# Altium schematic sheet HeartAware_Main.SchDoc
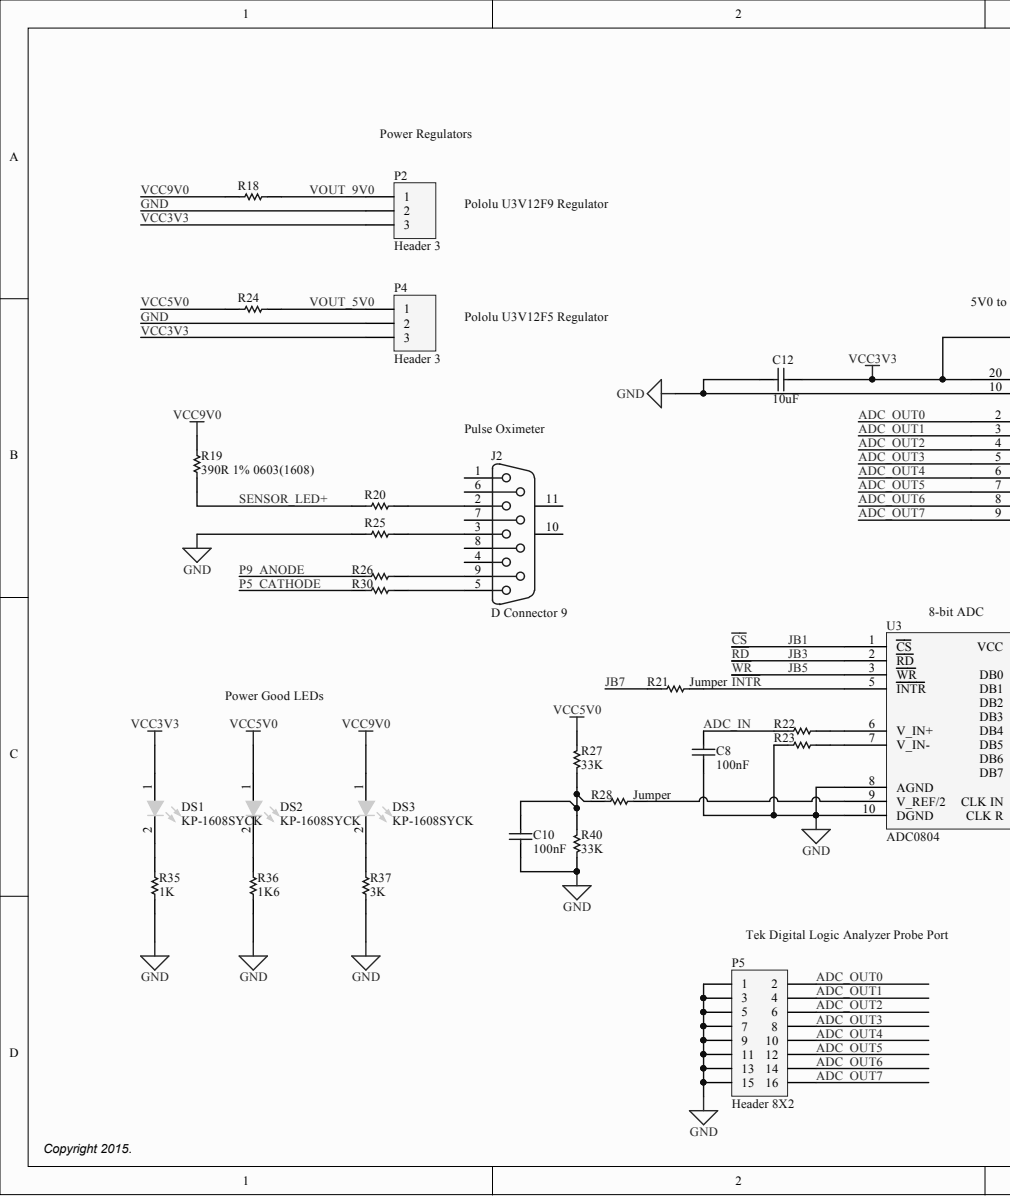
[diagram p0: HeartAware_Main.SchDoc, left]
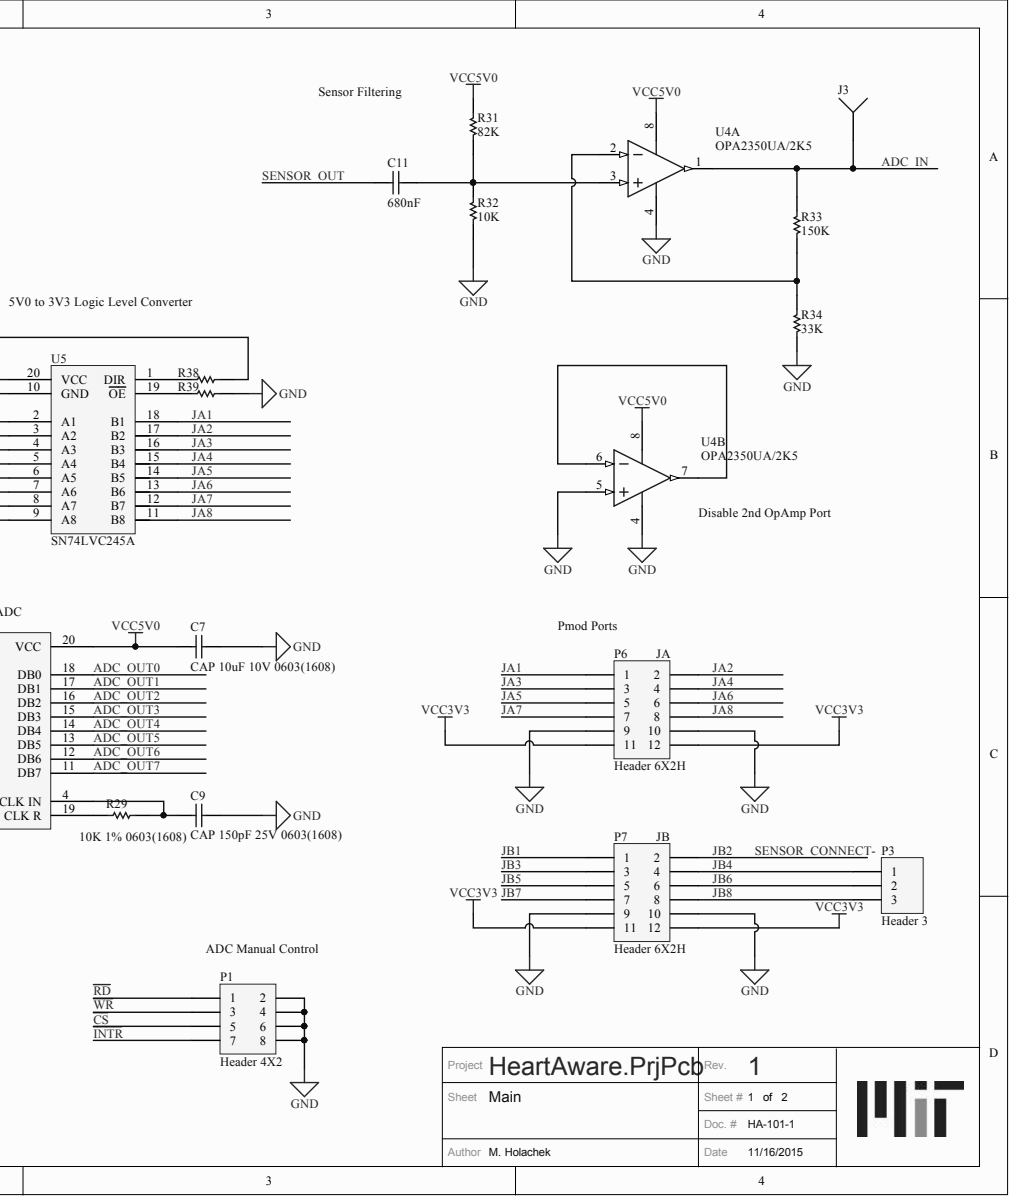
[diagram p1: HeartAware_Main.SchDoc, right]

## Parts
C7  CAP 10uF 10V 0603(1608)  value 10uF  [CAPC0603(1608)100_N]
C8  100nF  [CAPC0603(1608)100_M]
C9  CAP 150pF 25V 0603(1608)  value 150pF  [CAPC0603(1608)100_L]
C10  100nF  [CAPC0603(1608)100_M]
C11  680nF  [CAPC0603(1608)100_N]
C12  10uF  [CAPC0603(1608)100_N]
DS1  KP-1608SYCK  [KING-0603LED_YELLOW_V]
DS2  KP-1608SYCK  [KING-0603LED_YELLOW_V]
DS3  KP-1608SYCK  [KING-0603LED_YELLOW_V]
J2  D Connector 9  [DSUB1.385-2H9]
J3  Socket  [PIN1]  (value not drawn)
P1  Header 4X2  [HDR2X4]
P2  Header 3  [HDR1X3]
P3  Header 3  [HDR1X3]
P4  Header 3  [HDR1X3]
P5  Header 8X2  [HDR2X8]
P6  Header 6X2H  [HDR2X6H]
P7  Header 6X2H  [HDR2X6H]
R18  Jumper 0603(1608)  [RESC0603(1608)_N]  (value not drawn)
R19  390R 1% 0603(1608)  [RESC0603(1608)_L]
R20  Jumper 0603(1608)  [RESC0603(1608)_L]  (value not drawn)
R21  Jumper  [RESC0603(1608)_N]
R22  Jumper  [RESC0603(1608)_N]  (value not drawn)
R23  Jumper  [RESC0603(1608)_N]  (value not drawn)
R24  Jumper 0603(1608)  [RESC0603(1608)_N]  (value not drawn)
R25  Jumper 0603(1608)  [RESC0603(1608)_L]  (value not drawn)
R26  Jumper 0603(1608)  [RESC0603(1608)_L]  (value not drawn)
R27  33K  [RESC0603(1608)_N]
R28  Jumper  [RESC0603(1608)_N]
R29  10K 1% 0603(1608)  [RESC0603(1608)_N]
R30  Jumper 0603(1608)  [RESC0603(1608)_L]  (value not drawn)
R31  82K  [RESC0603(1608)_N]
R32  10K  [RESC0603(1608)_N]
R33  150K  [RESC0603(1608)_L]
R34  33K  [RESC0603(1608)_N]
R35  1K  [RESC0603(1608)_M]
R36  1K6  [RESC0603(1608)_L]
R37  3K  [RESC0603(1608)_N]
R38  Jumper 0603(1608)  [RESC0603(1608)_N]  (value not drawn)
R39  Jumper 0603(1608)  [RESC0603(1608)_N]  (value not drawn)
R40  33K  [RESC0603(1608)_N]
U3  ADC0804  [SOIC127P1030X265-20N]
U4  OPA2350UA/2K5  [TI-D8_M]  (parts A,B)
U5  SN74LVC245A  [SOIC127P780X200-20N]

## Nets
~{CS}: P1.5 P7.1 U3.1(CS)
~{INTR}: P1.7 R21.2 U3.5(INTR)
~{RD}: P1.1 P7.3 U3.2(RD)
~{WR}: P1.3 P7.5 U3.3(WR)
ADC_IN: C8.2 J3.1 R22.1 R33.1 U4.1
ADC_OUT0: P5.2 U3.18(DB0) U5.2(A1)
ADC_OUT1: P5.4 U3.17(DB1) U5.3(A2)
ADC_OUT2: P5.6 U3.16(DB2) U5.4(A3)
ADC_OUT3: P5.8 U3.15(DB3) U5.5(A4)
ADC_OUT4: P5.10 U3.14(DB4) U5.6(A5)
ADC_OUT5: P5.12 U3.13(DB5) U5.7(A6)
ADC_OUT6: P5.14 U3.12(DB6) U5.8(A7)
ADC_OUT7: P5.16 U3.11(DB7) U5.9(A8)
GND: C7.1 C8.1 C9.2 C10.1 C12.2 P1.2 P1.4 P1.6 P1.8 P2.2 P4.2 P5.1 P5.3 P5.5 P5.7 P5.9 P5.11 P5.13 P5.15 P6.9 P6.10 P7.9 P7.10 R23.1 R25.1 R32.2 R34.2 R35.1 R36.1 R37.1 R39.2 R40.2 U3.8(AGND) U3.10(DGND) U4.4 U4.5 U5.10(GND)
JA1: P6.1 U5.18(B1)
JA2: P6.2 U5.17
JA3: P6.3 U5.16
JA4: P6.4 U5.15
JA5: P6.5 U5.14
JA6: P6.6 U5.13
JA7: P6.7 U5.12
JA8: P6.8 U5.11
JB2: P7.2
JB4: P3.1 P7.4
JB6: P3.2 P7.6
JB7: P7.7 R21.1
JB8: P3.3 P7.8
P5_CATHODE: R30.1
P9_ANODE: R26.1
SENSOR_LED+: R19.1 R20.1
SENSOR_OUT: C11.1
VCC3V3: C12.1 DS1.1 P2.3 P4.3 P6.11 P6.12 P7.11 P7.12 R38.2 U5.20(VCC)
VCC5V0: C7.2 DS2.1 R24.1 R27.1 R31.1 U3.20(VCC) U4.8
VCC9V0: DS3.1 R18.1 R19.2
VOUT_5V0: P4.1 R24.2
VOUT_9V0: P2.1 R18.2
NetC9_1: C9.1 R29.2 U3.4(CLK_IN)
NetC10_2: C10.2 R27.2 R28.1 R40.1
NetC11_2: C11.2 R31.2 R32.1 U4.3
NetDS1_2: DS1.2 R35.2
NetDS2_2: DS2.2 R36.2
NetDS3_2: DS3.2 R37.2
NetJ2_2: J2.2 R20.2
NetJ2_3: J2.3 R25.2
NetJ2_5: J2.5 R30.2
NetJ2_9: J2.9 R26.2
NetR22_2: R22.2 U3.6(V_IN+)
NetR23_2: R23.2 U3.7(V_IN-)
NetR28_2: R28.2 U3.9(V_REF/2)
NetR29_1: R29.1 U3.19(CLK_R)
NetR33_2: R33.2 R34.1 U4.2
NetR38_1: R38.1 U5.1(DIR)
NetR39_1: R39.1 U5.19(OE)
NetU4_6: U4.6 U4.7

Not connected: J2 1 4 6 7 8 10 11

## Library data of the parts
C7 C12 = CMP-1035-02799-1: CAP 10uF 10V Â±10% 0603 (1608 Metric) Thickness 1mm SMD; Case-EIA: 0603; Case-Metric: 1608; Component Kind: Standard; Library Name: Altium Content Vault; Max Thickness: 1 mm; Rated Voltage: 10 V; Technology: SMT; Tolerance: Â±10%
  C12: Value: 10uF
C8 C10 = CMP-1035-02588-1: CAP 100nF 25V Â±10% 0603 (1608 Metric) Thickness 1mm SMD; Case-EIA: 0603; Case-Metric: 1608; Component Kind: Standard; Library Name: Altium Content Vault; Max Thickness: 1 mm; Rated Voltage: 25 V; Technology: SMT; Tolerance: Â±10%; Value: 100nF
C9 = CMP-1035-01361-1: CAP 150pF 25V Â±2% 0603 (1608 Metric) Thickness 1mm SMD; Case-EIA: 0603; Case-Metric: 1608; Component Kind: Standard; Library Name: Altium Content Vault; Max Thickness: 1 mm; Rated Voltage: 25 V; Technology: SMT; Tolerance: Â±2%
C11 = CMP-1035-02722-1: CAP 680nF 25V Â±10% 0603 (1608 Metric) Thickness 1mm SMD; Case-EIA: 0603; Case-Metric: 1608; Component Kind: Standard; Library Name: Altium Content Vault; Max Thickness: 1 mm; Rated Voltage: 25 V; Technology: SMT; Tolerance: Â±10%; Value: 680nF
DS1-DS3 = CMP-0404-00003-1: LED, SMT, 0603(1608), 1.1mm thickness, Yellow; Manufacturer: Kingbright; Forward Current: 30 mA; Packing Type: 2000 pcs / reel; Power Dissipation: 75 mW; Reverse Voltage: 5 V; Component Kind: Standard; ComponentLink1Description: Manufacturer URL; ComponentLink1URL: http://www.kingbright.com/; ComponentLink2Description: Datasheet; ComponentLink2URL: http://www.kingbright.com/manager/upload/pdf/(1366274739)KP-1608SYCK(Ver.18B).pdf; Library Name: Altium Content Vault; Mounting Technology: Surface Mount; PackageDescription: 2-Pin SMT LED, 1.6 x 0.8 mm Pitch; PackageReference: 0603LED_YELLOW; PartNumber: KP-1608SYCK; Storage Temperature: -40 to +85 degC
J2 = D Connector 9: Receptacle Assembly, 9 Position, Right Angle; PackageDocument: O; Positions: 9; Component Kind: Standard; DatasheetDocument: 1-Sep-1999; Gender: Receptacle; LatestRevisionDate: 17-Jul-2002; LatestRevisionNote: Re-released for DXP Platform.; Library Name: Miscellaneous Connectors.IntLib; Orientation: Right Angle; PackageReference: 788750; Published: 15-Jun-2001; Signal Integrity: Connector; Publisher: Altium Limited
P1 = Header 4X2: Header, 4-Pin, Dual row; Component Kind: Standard; LatestRevisionDate: 17-Jul-2002; LatestRevisionNote: Re-released for DXP Platform.; Library Name: Miscellaneous Connectors.IntLib; Signal Integrity: Connector; Publisher: Altium Limited
P2-P4 = Header 3: Header, 3-Pin; Component Kind: Standard; LatestRevisionDate: 17-Jul-2002; LatestRevisionNote: Re-released for DXP Platform.; Library Name: Miscellaneous Connectors.IntLib; Signal Integrity: Connector; Publisher: Altium Limited
P5 = Header 8X2: Header, 8-Pin, Dual row; Component Kind: Standard; LatestRevisionDate: 17-Jul-2002; LatestRevisionNote: Re-released for DXP Platform.; Library Name: Miscellaneous Connectors.IntLib; Signal Integrity: Connector; Publisher: Altium Limited
P6 P7 = Header 6X2H: Header, 6-Pin, Dual row, Right Angle; Component Kind: Standard; LatestRevisionDate: 17-Jul-2002; LatestRevisionNote: Re-released for DXP Platform.; Library Name: Miscellaneous Connectors.IntLib; Signal Integrity: Connector; Publisher: Altium Limited
R18 R20-R26 R28 R30 R38 R39 = CMP-1012-00001-1: Jumper 0603 (1608 Metric); Case-EIA: 0603; Case-Metric: 1608; Power: None; Value: 0.00 Ohm; Component Kind: Standard; Library Name: Altium Content Vault; Technology: SMT; Tolerance: None
R19 = CMP-1012-00463-1: 390R 0.1W 1% 0603 (1608 Metric)  SMD; Case-EIA: 0603; Case-Metric: 1608; Value: 390.00 Ohm; Component Kind: Standard; Library Name: Altium Content Vault; Power: 0.1 W; Technology: SMT; Tolerance: 1 %
R27 R34 R40 = CMP-1012-00680-1: 33K 0.1W 1% 0603 (1608 Metric)  SMD; Case-EIA: 0603; Case-Metric: 1608; Value: 33000.00 Ohm; Component Kind: Standard; Library Name: Altium Content Vault; Power: 0.1 W; Technology: SMT; Tolerance: 1 %
R29 R32 = CMP-1012-00623-1: 10K 0.1W 1% 0603 (1608 Metric)  SMD; Case-EIA: 0603; Case-Metric: 1608; Value: 10000.00 Ohm; Component Kind: Standard; Library Name: Altium Content Vault; Power: 0.1 W; Technology: SMT; Tolerance: 1 %
R31 = CMP-1012-00726-1: 82K 0.1W 1% 0603 (1608 Metric)  SMD; Case-EIA: 0603; Case-Metric: 1608; Value: 82000.00 Ohm; Component Kind: Standard; Library Name: Altium Content Vault; Power: 0.1 W; Technology: SMT; Tolerance: 1 %
R33 = CMP-1012-00754-1: 150K 0.1W 1% 0603 (1608 Metric)  SMD; Case-EIA: 0603; Case-Metric: 1608; Value: 150000.00 Ohm; Component Kind: Standard; Library Name: Altium Content Vault; Power: 0.1 W; Technology: SMT; Tolerance: 1 %
R35 = CMP-1012-00510-1: 1K 0.1W 1% 0603 (1608 Metric)  SMD; Case-EIA: 0603; Case-Metric: 1608; Value: 1000.00 Ohm; Component Kind: Standard; Library Name: Altium Content Vault; Power: 0.1 W; Technology: SMT; Tolerance: 1 %
R36 = CMP-1012-00531-1: 1K6 0.1W 1% 0603 (1608 Metric)  SMD; Case-EIA: 0603; Case-Metric: 1608; Value: 1600.00 Ohm; Component Kind: Standard; Library Name: Altium Content Vault; Power: 0.1 W; Technology: SMT; Tolerance: 1 %
R37 = CMP-1012-00562-1: 3K 0.1W 1% 0603 (1608 Metric)  SMD; Case-EIA: 0603; Case-Metric: 1608; Value: 3000.00 Ohm; Component Kind: Standard; Library Name: Altium Content Vault; Power: 0.1 W; Technology: SMT; Tolerance: 1 %
U3 = ADC0804: 8-Bit Parallel Analog-to-Digital Converter; Component Kind: Standard; Library Name: IC.IntLib
U4 = CMP-0272-00732-2: High-Speed, Single-Supply, Rail-to-Rail Operational Amplifier MicroAmplifier(TM) Series, 2.7 to 5.5 V, -40 to 85 degC, 8-pin SOIC (D8), Green (RoHS & no Sb/Br); Manufacturer: Texas Instruments; CMRR(Min)(dB): 74; IIB(Max)(pA): 10; Iq per channel(Max)(mA): 8.5; Number of Channels: 2; Offset Drift(Typ)(uV/C): 4; Slew Rate(Typ)(V/us): 22; Sub Family: Low Input Bias Current Amp (<=10pA), Low Offset Voltage Amplifier (<=500uV), Low Supply Voltage Amplifier (<=2.7V), Precision Amplifier; Total Supply Voltage(Max)(+5V is 5, +/-5V is 10): 5.5; Total Supply Voltage(Min)(+5V is 5, +/-5V is 10): 2.7; Vio (25C)(Max)(mV): 1; Vn at 1kHz(Typ)(nV/rtHz): 18; Code_JEDEC: MS-012; Component Kind: Standard; ComponentLink1Description: Manufacturer URL; ComponentLink1URL: http://www.ti.com/; ComponentLink2Description: Datasheet; ComponentLink2URL: http://www.ti.com/general/docs/lit/getliterature.tsp?genericPartNumber=OPA2350&fileType=pdf; ComponentLink3Description: Package Specification; ComponentLink3URL: http://www.ti.com/litv/pdf/msoi002j; DatasheetVersion: SBOS099C; Eco-Plan: Green (RoHS & no Sb/Br); Library Name: Altium Content Vault; Mounting Technology: Surface Mount; PackageDescription: 8-Pin Plastic Small Outline, Body 4.9 x 3.9 mm, Pitch 1.27 mm; PackageReference: D8; PackageVersion: 4040047-3/M, 06/2011; PartNumber: OPA2350UA/2K5
U5 = SN74LVC245A: Octal Bus Tranceiver With 3-State Outputs; Component Kind: Standard; Library Name: IC.IntLib
J3 = Socket: Component Kind: Standard; Description: Socket; LatestRevisionDate: 17-Jul-2002; LatestRevisionNote: Re-released for DXP Platform.; Library Name: Miscellaneous Connectors.IntLib; Signal Integrity: Connector; Publisher: Altium Limited
